# Altium schematic sheet 0-Power.SchDoc(0-Power)
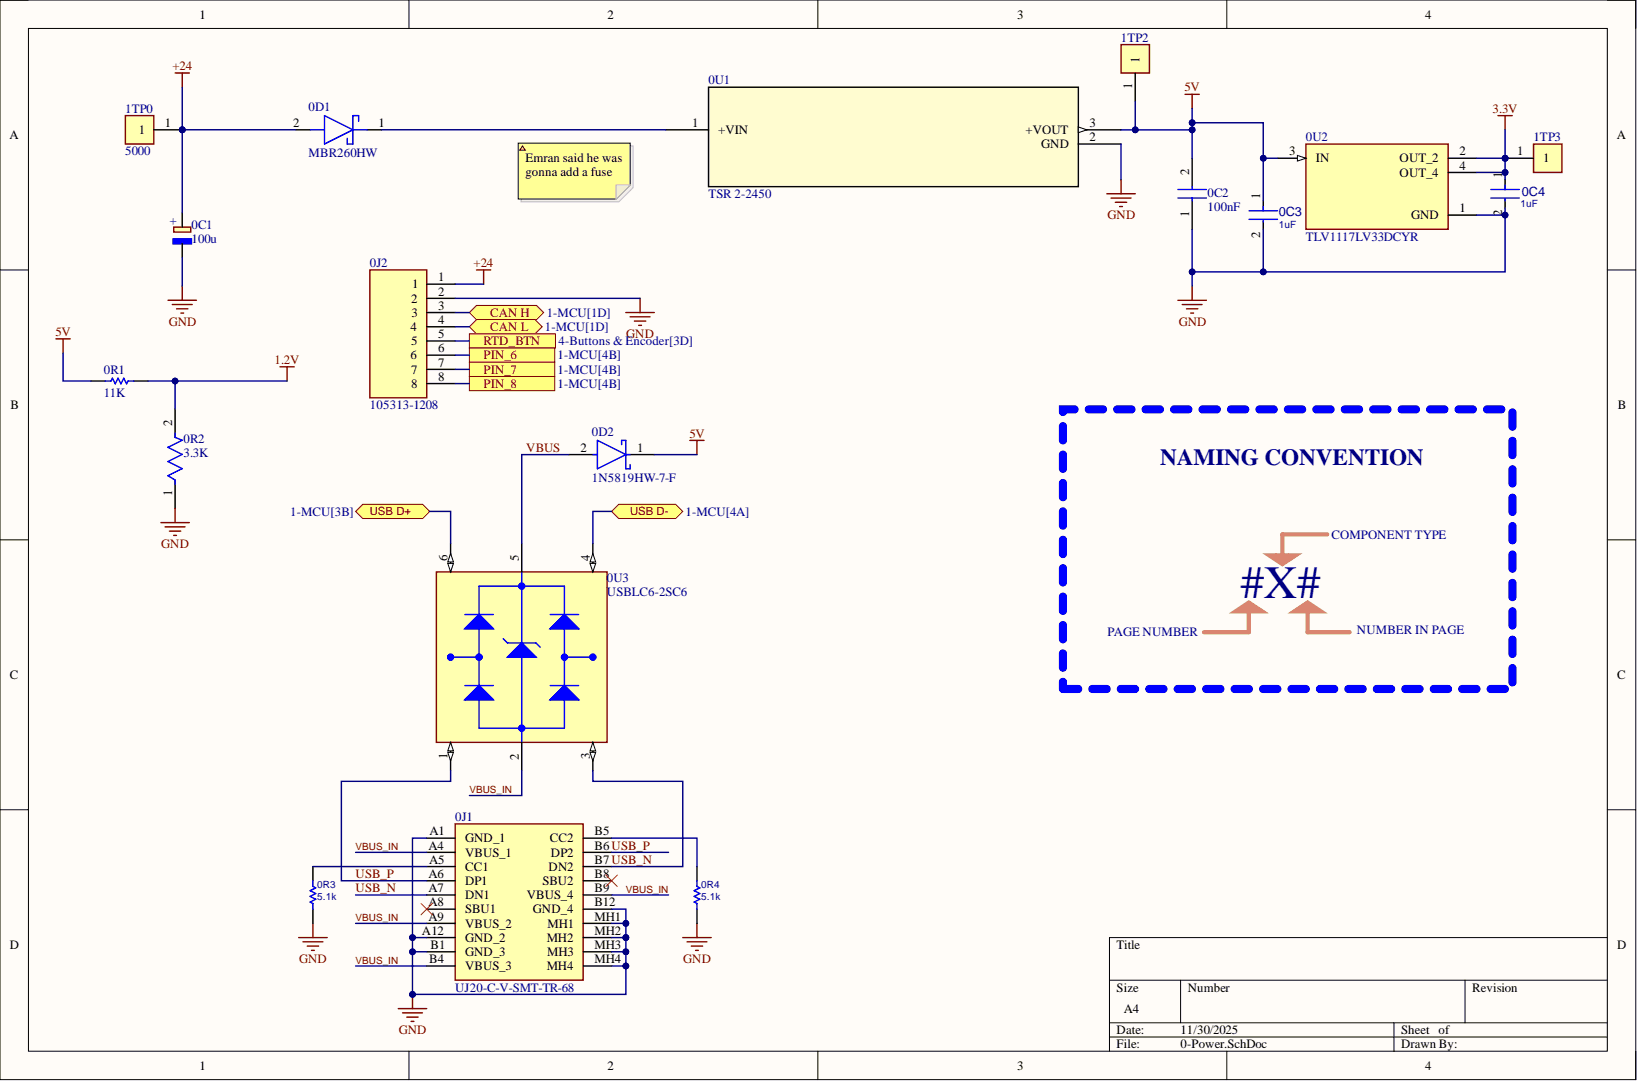
[diagram p0: 0-Power.SchDoc]

## Parts
0C1  value 100u  (hidden comment: 865080545012)
0C2  100nF
0C3  1uF
0C4  1uF
0D1  MBR260HW
0D2  1N5819HW-7-F
0J1  UJ20-C-V-SMT-TR-68
0J2  105313-1208
0R1  11K
0R2  3.3K
0R3  5.1k
0R4  5.1k
0U1  TSR 2-2450
0U2  TLV1117LV33DCYR
0U3  USBLC6-2SC6
1TP0  5000
1TP2  5000  (value not drawn)
1TP3  5000  (value not drawn)

## Ports
CAN H, CAN L, PIN_6, PIN_7, PIN_8, RTD_BTN, USB D+, USB D-

## Nets
1.2V: 0R1.2 0R2.2
3.3V: 0C4.1 0U2.2(OUT_2) 0U2.4(OUT_4) 1TP3.1
5V: 0C2.2 0C3.1 0D2.1 0R1.1 0U1.3 0U2.3(IN) 1TP2.1
+24: 0C1.POS 0D1.2 0J2.1 1TP0.1
GND: 0C1.NEG 0C2.1 0C3.2 0C4.2 0J1.A1(GND_1) 0J1.A12(GND_2) 0J1.B1(GND_3) 0J1.B12(GND_4) 0J1.MH1 0J1.MH2 0J1.MH3 0J1.MH4 0J2.2 0R2.1 0R3.1 0R4.1 0U1.2 0U2.1(GND)
CAN H: 0J2.3 ; port CAN H
CAN L: 0J2.4 ; port CAN L
PIN_6: 0J2.6 ; port PIN_6
PIN_7: 0J2.7 ; port PIN_7
PIN_8: 0J2.8 ; port PIN_8
RTD_BTN: 0J2.5 ; port RTD_BTN
USB_N: 0J1.A7(DN1) 0J1.B7(DN2) 0U3.3
USB_P: 0J1.A6(DP1) 0J1.B6(DP2) 0U3.1
VBUS: 0D2.2 0U3.5
VBUS_IN: 0J1.A4(VBUS_1) 0J1.A9(VBUS_2) 0J1.B4(VBUS_3) 0J1.B9(VBUS_4) 0U3.2
Net0D1_1: 0D1.1 0U1.1
Net0J1_A5: 0J1.A5(CC1) 0R3.2
Net0J1_B5: 0J1.B5(CC2) 0R4.2
Net0U3_4: 0U3.4
Net0U3_6: 0U3.6

Not connected: 0J1 A8(SBU1) B8(SBU2)

## Library data of the parts
0C1 = CMP-174-000000-1: 100 ÂµF 35 V Aluminum Electrolytic Capacitors Radial, Can - SMD - 2000 Hrs @ 105Â°C; MF: WÃ¼rth Elektronik; Availability: In Stock; Check_prices: https://www.snapeda.com/parts/865080545012/Wurth+Elektronik/view-part/?ref=eda; Component Kind: Standard; Component Type: Standard; Design Item ID: 865080545012; MP: 865080545012; Package: Radial WÃ¼rth Elektronik; Pin Count: 2; Price: None; Purchase-URL: https://pricing.snapeda.com/search/part/865080545012/?ref=eda; SnapEDA_Link: https://www.snapeda.com/parts/865080545012/Wurth+Elektronik/view-part/?ref=snap; Source: 865080545012.SchLib; Library Name: Western Formula Racing
0C2 = CL10B104KA8NNNC: Manufacturer_Name: SAMSUNG; Arrow Part Number: CL10B104KA8NNNC; Arrow Price/Stock: https://www.arrow.com/en/products/cl10b104ka8nnnc/samsung-electro-mechanics?utm_currency=USD&region=europe; Component Kind: Standard; Datasheet Link: http://media.digikey.com/pdf/Data%20Sheets/Samsung%20PDFs/CL_Series_MLCC_ds.pdf; Description: CL10B104KA8NNNC; Height: 0.9mm; Manufacturer_Part_Number: CL10B104KA8NNNC; Mouser Part Number: 187-CL10B104KA8NNNC; Mouser Price/Stock: https://www.mouser.co.uk/ProductDetail/Samsung-Electro-Mechanics/CL10B104KA8NNNC?qs=349EhDEZ59rmu3pGeZXx0A%3D%3D
0C3 0C4 = CMP-2000-05447-3: UMK107BJ105KA-T; Dielectric: X5R; Tolerance: 10%; Voltage Rating: 50V; Capacitance: 1uF; Case Code (Imperial): 0603; Case Code (Metric): 1608; Case/Package: 0603; Component Kind: Standard; Construction: Flat; Contact Plating: Nickel; Depth: 0.8mm; Halogen Free: Halogen Free; Height: 0.8mm; Length: 1.6mm; Library Name: Altium Content Vault; Max Operating Temperature: 85°C; Min Operating Temperature: -55°C; Mount: Surface Mount; Number of Pins: 2; Package Quantity: 1; Packaging: Tape and Reel; Radiation Hardening: No; RoHS Compliant: Yes; Series: M; Termination: SMD/SMT; Thickness: 0.035inch; Voltage Rating (DC): 50V; Width: 0.031inch
0D1 = MBR260HW: Schottky Diode; Manufacturer_Name: SMC Diode Solutions; Component Kind: Standard; Datasheet Link: https://www.smc-diodes.com/propdf/MBR260HW%20N1503%20REV.B.pdf; Height: 1.25mm; Manufacturer_Part_Number: MBR260HW
0D2 = CMP-0303-00003-3: DIODE SCHOTTKY 40V 1A SOD123; Capacitance: 110pF; Element Configuration: Single; Forward Voltage: 450mV; Max Forward Surge Current (Ifsm): 25A; Max Power Dissipation: 450mW; Peak Reverse Current: 50uA; Polarity: Standard; Average Rectified Current: 1A; Case/Package: SOD-123-2; Component Kind: Standard; Contact Plating: Tin; Current Rating: 1A; Forward Current: 1A; Height: 1.25mm; Lead Free: Lead Free; Length: 2.85mm; Library Name: Altium Content Vault; Max Operating Temperature: 125°C; Max Repetitive Reverse Voltage (Vrrm): 40V; Max Reverse Voltage (DC): 40V; Min Operating Temperature: -65°C; Number of Pins: 2; Output Current: 1A; Package Quantity: 3000; Packaging: Tape and Reel; Peak Non-Repetitive Surge Current: 25A; Radiation Hardening: No; REACH SVHC: No SVHC; Termination: SMD/SMT; Voltage Rating (DC): 40V; Weight: 0.000353oz; Width: 1.7mm
0J1 = UJ20-C-V-SMT-TR-68: Connector; Manufacturer_Name: Same Sky; Arrow Part Number: UJ20-C-V-SMT-TR-68; Arrow Price/Stock: https://www.arrow.com/en/products/uj20-c-v-smt-tr-68/cui-devices?utm_currency=USD&region=nac; Component Kind: Standard; Datasheet Link: https://www.sameskydevices.com/product/resource/uj20-c-v-smt-tr-68.pdf; Height: 6.4mm; Manufacturer_Part_Number: UJ20-C-V-SMT-TR-68; Mouser Part Number: 179-UJ20-C-V-SMTTR68; Mouser Price/Stock: https://www.mouser.co.uk/ProductDetail/Same-Sky/UJ20-C-V-SMT-TR-68?qs=HoCaDK9Nz5dJB%252B3Mk1F%2F5A%3D%3D
0J2 = 105313-1208: Connector; Manufacturer_Name: Molex; Arrow Part Number: 105313-1208; Arrow Price/Stock: https://www.arrow.com/en/products/1053131208/molex; Component Kind: Standard; Datasheet Link: https://componentsearchengine.com/Datasheets/1/105313-1208.pdf; Height: 6.84mm; Manufacturer_Part_Number: 105313-1208; Mouser Part Number: 538-105313-1208; Mouser Price/Stock: https://www.mouser.co.uk/ProductDetail/Molex/105313-1208?qs=PqFvFmEiOr6hMgoFYdPx0g%3D%3D
0R1 = CMP-2001-04638-1: RK73B2ATTD113J; Manufacturer: KOA Speer; Component Kind: Standard; Library Name: Altium Content Vault; Part Number: RK73B2ATTD113J
0R2 = CMP-08839-028322-1: ESR10EZPJ332 - 3.3kΩ ±5% 0.4W 0805 Anti-Surge Chip Resistor AEC-Q200; Max Power Dissipation: 400mW; Resistance: 3.3kR; Temperature Coefficient: 200ppm/°C; Case Code (Imperial): 0805; Case Code (Metric): 2012; Case/Package: 0805; Component Kind: Standard; Features: Automotive AEC-Q200; Height: 0.026inch; Length: 0.079inch; Library Name: Altium Content Vault; Max Operating Temperature: 155°C; Min Operating Temperature: -55°C; Number of Terminations: 2; Package Quantity: 1; Packaging: Tape and Reel; Resistor Type: Thick Film; RoHS Compliant: Yes; Series: ESR; Tolerance: 5%; Width: 0.049inch
0R3 0R4 = CMP-2000-04091-1: RC0603FR-075K1L; Manufacturer: Yageo; Component Kind: Standard; Library Name: Altium Content Vault; Part Number: RC0603FR-075K1L
0U1 = CMP-49942-000013-2: DC DC CONVERTER 5V 10W; NexarPartId: 71799544; Max Supply Voltage: 36V; Min Supply Voltage: 6.5V; Number of Outputs: 1; Output Current: 2A; Switching Frequency: 1.2MHz; Case/Package: SIP; Component Kind: Standard; Height: 10.1mm; Library Name: Altium Content Vault; Max Operating Temperature: 85°C; Min Operating Temperature: -40°C; Mount: Through Hole; Output Power: 10W; Output Voltage: 5V; RoHS: Non-Compliant; Width: 7.5mm
0U2 = CMP-311-000000-1: 1-A, low-dropout voltage regulator; MF: Texas Instruments; Check_prices: https://www.snapeda.com/parts/TLV1117LV33DCYR/Texas+Instruments/view-part/?ref=eda; Component Kind: Standard; Component Type: Standard; Design Item ID: TLV1117LV33DCYR; Digi-Key_Part_Number: 296-28778-2-ND; MP: TLV1117LV33DCYR; Package: SOT-223-4 Texas Instruments; Pin Count: 4; Purchase-URL: https://pricing.snapeda.com/search/part/TLV1117LV33DCYR/?ref=eda; SnapEDA_Link: https://www.snapeda.com/parts/TLV1117LV33DCYR/Texas+Instruments/view-part/?ref=snap; Source: TLV1117LV33DCYR.SchLib; Library Name: Western Formula Racing
0U3 = CMP-004-00008-1: 17V Clamp 5A (8/20Âµs) Ipp Tvs Diode Surface Mount SOT-23-6; MF: STMicroelectronics; Availability: In Stock; Case/Package: SOT-23-6 STMicroelectronics; Check_prices: https://www.snapeda.com/parts/USBLC6-2SC6/STMicroelectronics/view-part/?ref=eda; Component Kind: Standard; Component Type: Standard; Design Item ID: USBLC6-2SC6; MP: USBLC6-2SC6; Pins: 6; Price: None; Purchase-URL: https://pricing.snapeda.com/search/part/USBLC6-2SC6/?ref=eda; SnapEDA_Link: https://www.snapeda.com/parts/USBLC6-2SC6/STMicroelectronics/view-part/?ref=snap; Source: USBLC6-2SC6.SchLib; Library Name: Western Formula Racing
1TP0 1TP2 1TP3 = CMP-19636-000027-1: PC TEST POINT MINIATURE RED; Body Material: Bronze; Hole Diameter: 1.6mm; Inside Diameter: 1.27mm; Insulation: Insulated; Number of Contacts: 1; Outside Diameter: 2.54mm; Plating: Silver; Color: Red; Component Kind: Standard; Contact Material: Bronze; Contact Plating: Tin; Flammability Rating: UL94 V-0; Height: 0.3inch; Housing Color: Red; Lead Free: Lead Free; Length: 7.62mm; Library Name: Altium Content Vault; Material: Nylon; Mount: PCB; Number of Ports: 1; Orientation: Straight; Package Quantity: 100; Packaging: Bulk; REACH SVHC: No SVHC; RoHS Compliant: Yes; Series: THM
